# Altium schematic sheet schematic.SchDoc
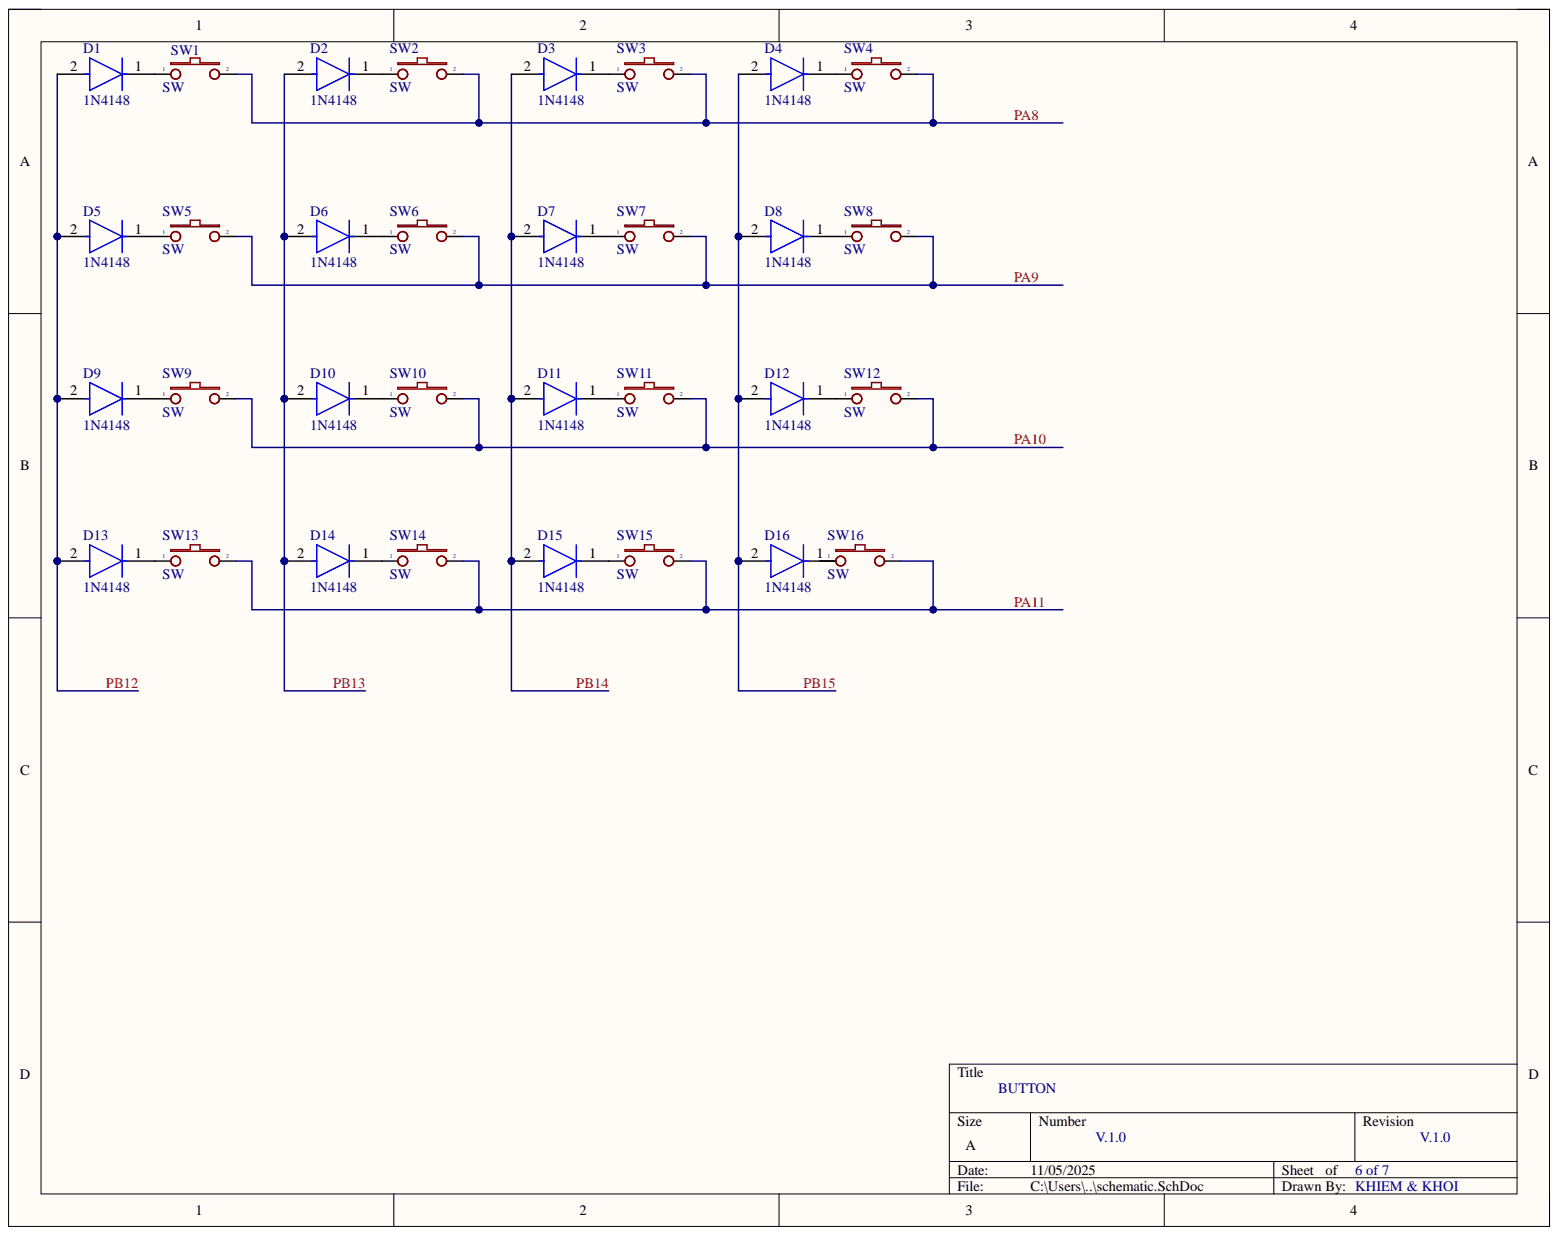
[diagram p0: schematic.SchDoc]

## Parts
D1  1N4148  [FP-017AG-MFG]
D2  1N4148  [FP-017AG-MFG]
D3  1N4148  [FP-017AG-MFG]
D4  1N4148  [FP-017AG-MFG]
D5  1N4148  [FP-017AG-MFG]
D6  1N4148  [FP-017AG-MFG]
D7  1N4148  [FP-017AG-MFG]
D8  1N4148  [FP-017AG-MFG]
D9  1N4148  [FP-017AG-MFG]
D10  1N4148  [FP-017AG-MFG]
D11  1N4148  [FP-017AG-MFG]
D12  1N4148  [FP-017AG-MFG]
D13  1N4148  [FP-017AG-MFG]
D14  1N4148  [FP-017AG-MFG]
D15  1N4148  [FP-017AG-MFG]
D16  1N4148  [FP-017AG-MFG]
SW1  SW  [SW2]
SW2  SW  [SW2]
SW3  SW  [SW2]
SW4  SW  [SW2]
SW5  SW  [SW2]
SW6  SW  [SW2]
SW7  SW  [SW2]
SW8  SW  [SW2]
SW9  SW  [SW2]
SW10  SW  [SW2]
SW11  SW  [SW2]
SW12  SW  [SW2]
SW13  SW  [SW2]
SW14  SW  [SW2]
SW15  SW  [SW2]
SW16  SW  [SW2]

## Nets
PA8: SW1.2 SW2.2 SW3.2 SW4.2
PA9: SW5.2 SW6.2 SW7.2 SW8.2
PA10: SW9.2 SW10.2 SW11.2 SW12.2
PA11: SW13.2 SW14.2 SW15.2 SW16.2
PB12: D1.2 D5.2 D9.2 D13.2
PB13: D2.2 D6.2 D10.2 D14.2
PB14: D3.2 D7.2 D11.2 D15.2
PB15: D4.2 D8.2 D12.2 D16.2
NetD1_1: D1.1 SW1.1
NetD2_1: D2.1 SW2.1
NetD3_1: D3.1 SW3.1
NetD4_1: D4.1 SW4.1
NetD5_1: D5.1 SW5.1
NetD6_1: D6.1 SW6.1
NetD7_1: D7.1 SW7.1
NetD8_1: D8.1 SW8.1
NetD9_1: D9.1 SW9.1
NetD10_1: D10.1 SW10.1
NetD11_1: D11.1 SW11.1
NetD12_1: D12.1 SW12.1
NetD13_1: D13.1 SW13.1
NetD14_1: D14.1 SW14.1
NetD15_1: D15.1 SW15.1

Not connected: D16 1; SW16 1

## Library data of the parts
D1-D16 = CMP-07163-000046-1: DIODE GEN PURP 100V 200MA DO35; Capacitance: 4pF; Current: 4A; Element Configuration: Single; Forward Current: 300mA; Forward Voltage: 1V; Max Forward Surge Current (Ifsm): 1A; Max Power Dissipation: 500mW; Max Reverse Current: 5uA; Outside Diameter: 1.85mm; Polarity: Standard; Recovery Time: 4ns; Average Rectified Current: 200mA; Case/Package: DO-35; Component Kind: Standard; Contact Plating: Tin; Current Rating: 200mA; Diameter: 1.91mm; Height: 4.56mm; Lead Free: Lead Free; Length: 4.56mm; Library Name: Altium Content Vault; Max Operating Temperature: 175°C; Max Repetitive Reverse Voltage (Vrrm): 100V; Max Reverse Voltage (DC): 100V; Max Surge Current: 4A; Min Operating Temperature: -65°C; Mount: Through Hole; Number of Pins: 2; Output Current: 200mA; Package Quantity: 2000; Packaging: Bulk; Peak Non-Repetitive Surge Current: 4A; Power Dissipation: 500mW; Radiation Hardening: No; REACH SVHC: No SVHC; Reverse Recovery Time: 4ns; Reverse Voltage: 100V; Series: -; Voltage: 100V; Voltage Rating (DC): 100V; Weight: 0.01lb; Width: 1.91mm
SW1-SW16 = SW2: Switch button 2 pin; Value: SW chip 2 pin; Component Kind: Standard; Library Name: syhaunguyen.IntLib
